# Altium schematic sheet Segway_Charging_Board_SheetName.SchDoc(Segway_Charging_Board_SheetName)
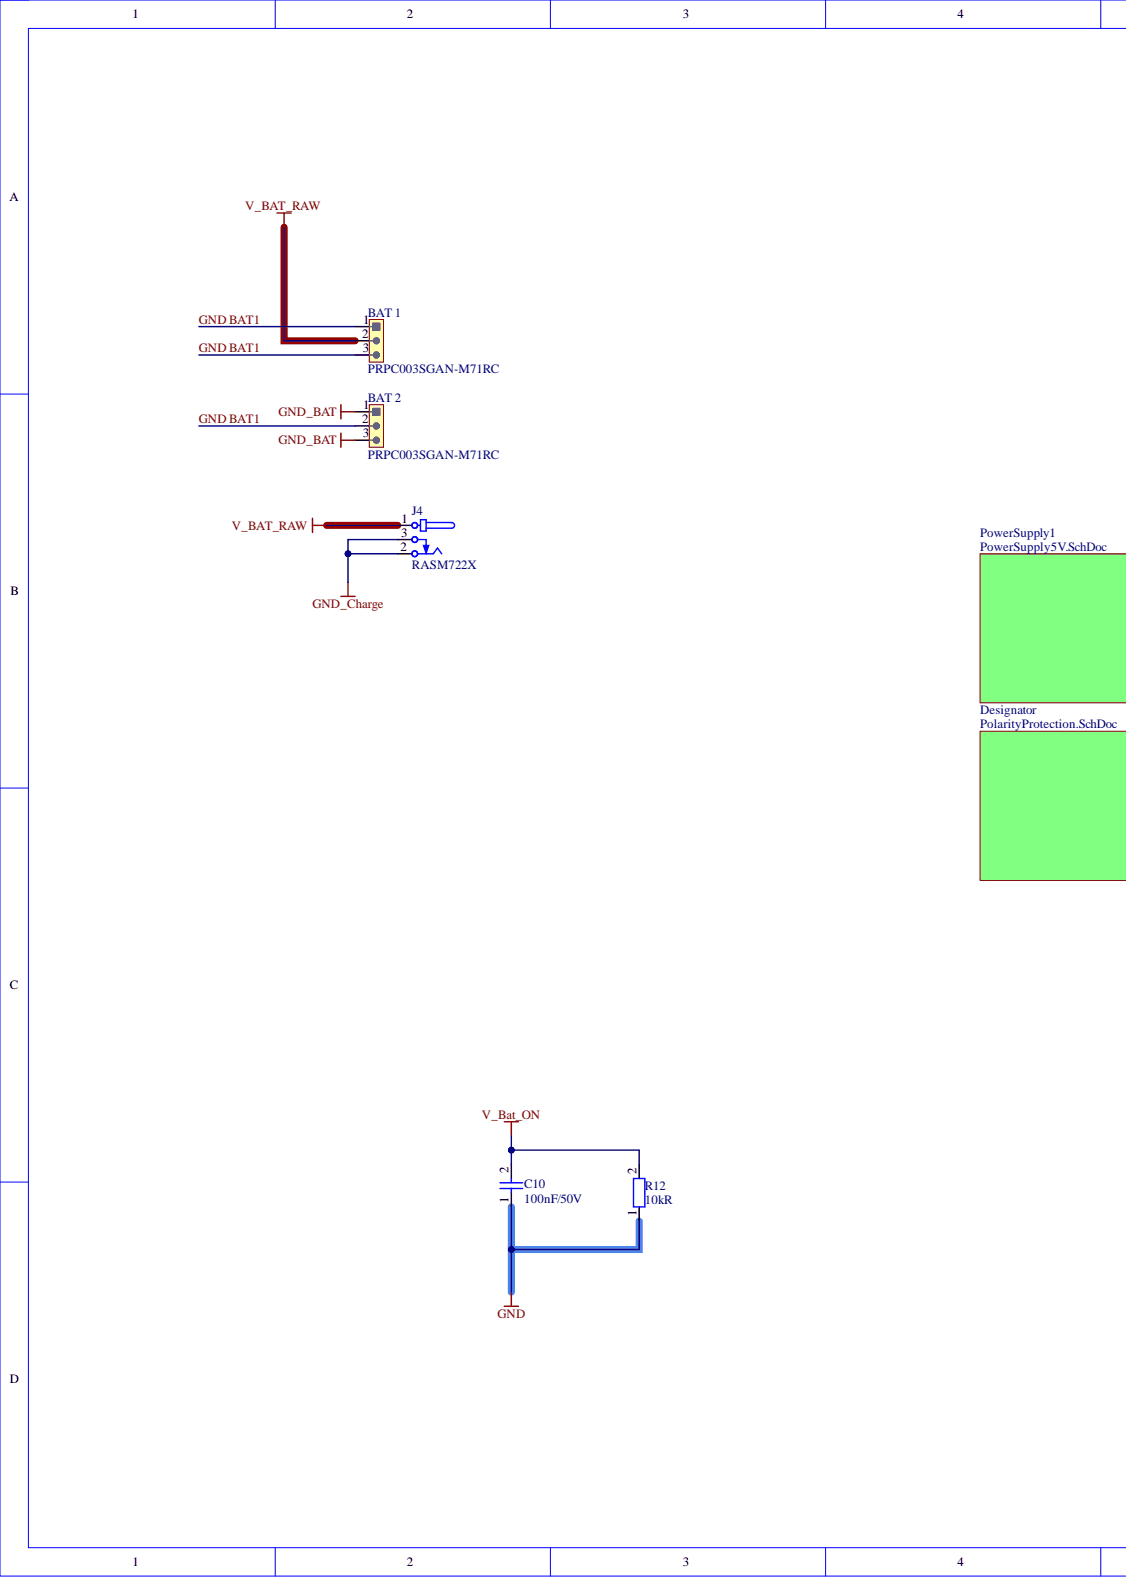
[diagram p0: Segway_Charging_Board_SheetName.SchDoc, left]
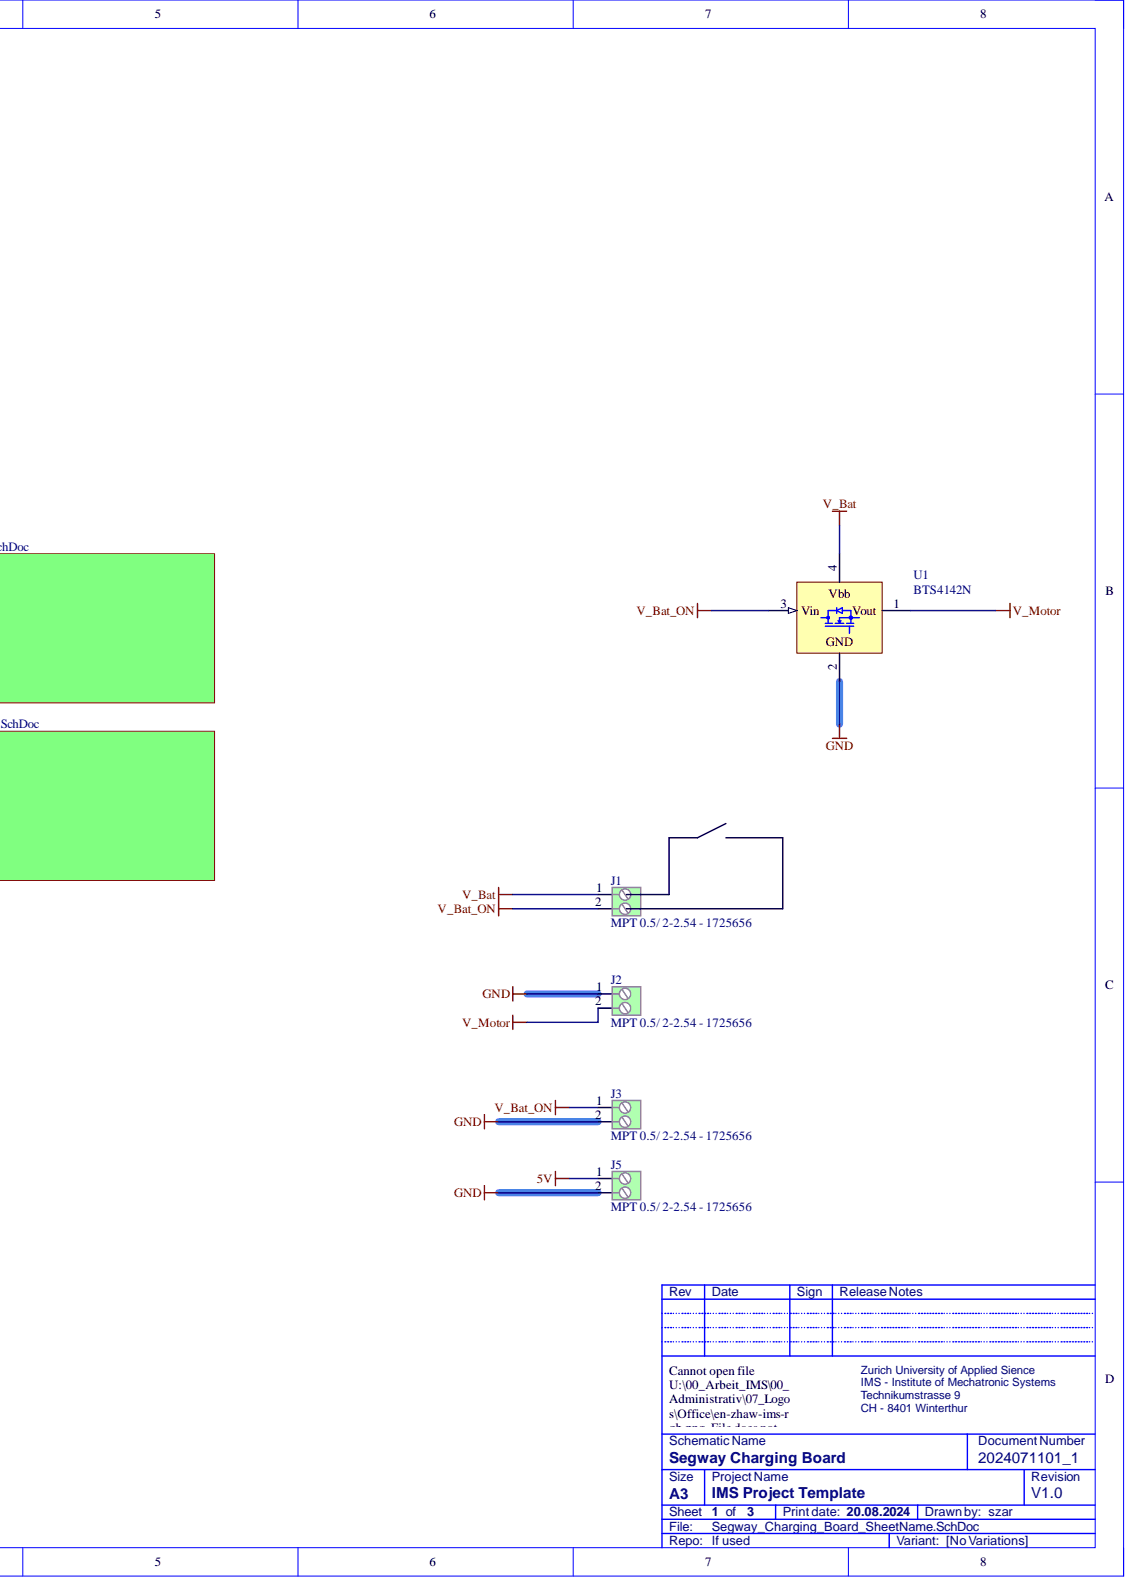
[diagram p1: Segway_Charging_Board_SheetName.SchDoc, right]

## Parts
BAT_1  PRPC003SGAN-M71RC  [HD254_1x3_MH]
BAT_2  PRPC003SGAN-M71RC  [HD254_1x3_MH]
C10  100nF/50V  [CAPC1005X05L]
J1  MPT 0.5/ 2-2.54 - 1725656  Family Name: MPT  [PHOENIX_1725656]
J2  MPT 0.5/ 2-2.54 - 1725656  Family Name: MPT  [PHOENIX_1725656]
J3  MPT 0.5/ 2-2.54 - 1725656  Family Name: MPT  [PHOENIX_1725656]
J4  RASM722X  [SWITCHCRAFT_RASM722X]
J5  MPT 0.5/ 2-2.54 - 1725656  Family Name: MPT  [PHOENIX_1725656]
R12  10kR  [RESC1005X04L]
U1  BTS4142N  [SOT230P700X180-4L]

## Nets
5V: J5.1
GND: C10.1 J2.1 J3.2 J5.2 R12.1 U1.2
GND_BAT: BAT_2.1 BAT_2.3
GND_Charge: J4.2 J4.3
V_Bat: J1.1 U1.4
V_Bat_ON: C10.2 J1.2 J3.1 R12.2 U1.3(Vin)
V_BAT_RAW: BAT_1.2 J4.1
V_Motor: J2.2 U1.1(Vout)
GND BAT1: BAT_1.1 BAT_1.3 BAT_2.2

## Library data of the parts
BAT_1 BAT_2 = CMP-002-00172-18: Header, 1x3Pin, Pitch 2.54mm, Male, Horizontal, THT; Manufacturer: Sullins Connector Solutions; Mating Length: 8.08m; Overall Contact Length: 0mm; Pin Mapping: 1x3; Angle: Horizontal; Component Kind: Standard; Gender: Male; Insulation Height: 2.5mm; Mounting Technology: THT; Pins: 3; Pitch: 2.54mm; Pitch Row: 2.54mm; Termination Length: 3.05mm; Library Name: ZHAW on Altium 365
C10 = CMP-001-00067-18: Cap MLCC, 100nF, 50V, X7R, 10%, 0402; Case Code (Metric): 1005; Case/Package: 0402; Component Kind: Standard; Dielectricum: X7R; Mounting Technology: SMD; Tolerance: 10%; Type: MLCC; Value: 100nF; Voltage Rating: 50V; Library Name: ZHAW on Altium 365
J1-J3 J5 = CMP-070-000011-17: Wire to Board, Screw, 2 Pin, Pitch 2.54mm, 6A, 160V, Horizontal, THT, L6.2B5.54H12; Manufacturer: Phoenix; Pin Mapping: 1x2; Angle: Horizontal; Component Kind: Standard; Connection: Screw; Max Current: 6A; Max Voltage: 160V; Mounting Technology: THT; Pins: 2; Pitch: 2.54mm; Size: L6.2B5.54H12; Type: Wire to Board; Library Name: ZHAW on Altium 365
J4 = CMP-002-00013-23: Barrel Power Jack, 3 Pin, Male, 5A, 250V, Horizontal, SMD, L14.4B9H11, Diameter 2.0/5.5mm; Manufacturer: Switchcraft; Mating Part: S760; Connection: Pluggable; Pin Mapping: 2+1; Pitch: 0mm; Angle: Horizontal; Component Kind: Standard; Gender: Male; Max Current: 5A; Max Voltage: 250V; Mounting Technology: SMD; Pins: 3; Size: L14.5B9H11; Type: Jack; Library Name: ZHAW on Altium 365
R12 = CMP-009-00149-32: Res, 10kR, 1%, 0.1W, 0402; Power: 100mW; Value: 10k; Voltage Rating: 50V; Case Code (Metric): 1005; Case/Package: 0402; Component Kind: Standard; Mounting Technology: SMD; Tolerance: 1%; Type: Fixed; Library Name: ZHAW on Altium 365
U1 = CMP-013-00047-18: High-Side Switch, 1 Ch, 12..45V, 1.4A, SOT-223-4; Channels: 1; Max Logic Voltage: 45V; Min Logic Voltage: 12V; Case/Package: SOT-223-4; Component Kind: Standard; Function: High-Side Switch; Max Current: 1.4A; Max Power Voltage: 45V; Min Power Voltage: 12V; Mounting Technology: SMD; Library Name: ZHAW on Altium 365
